# Altium schematic sheet CW308T_CEC1702_MICRO.SchDoc
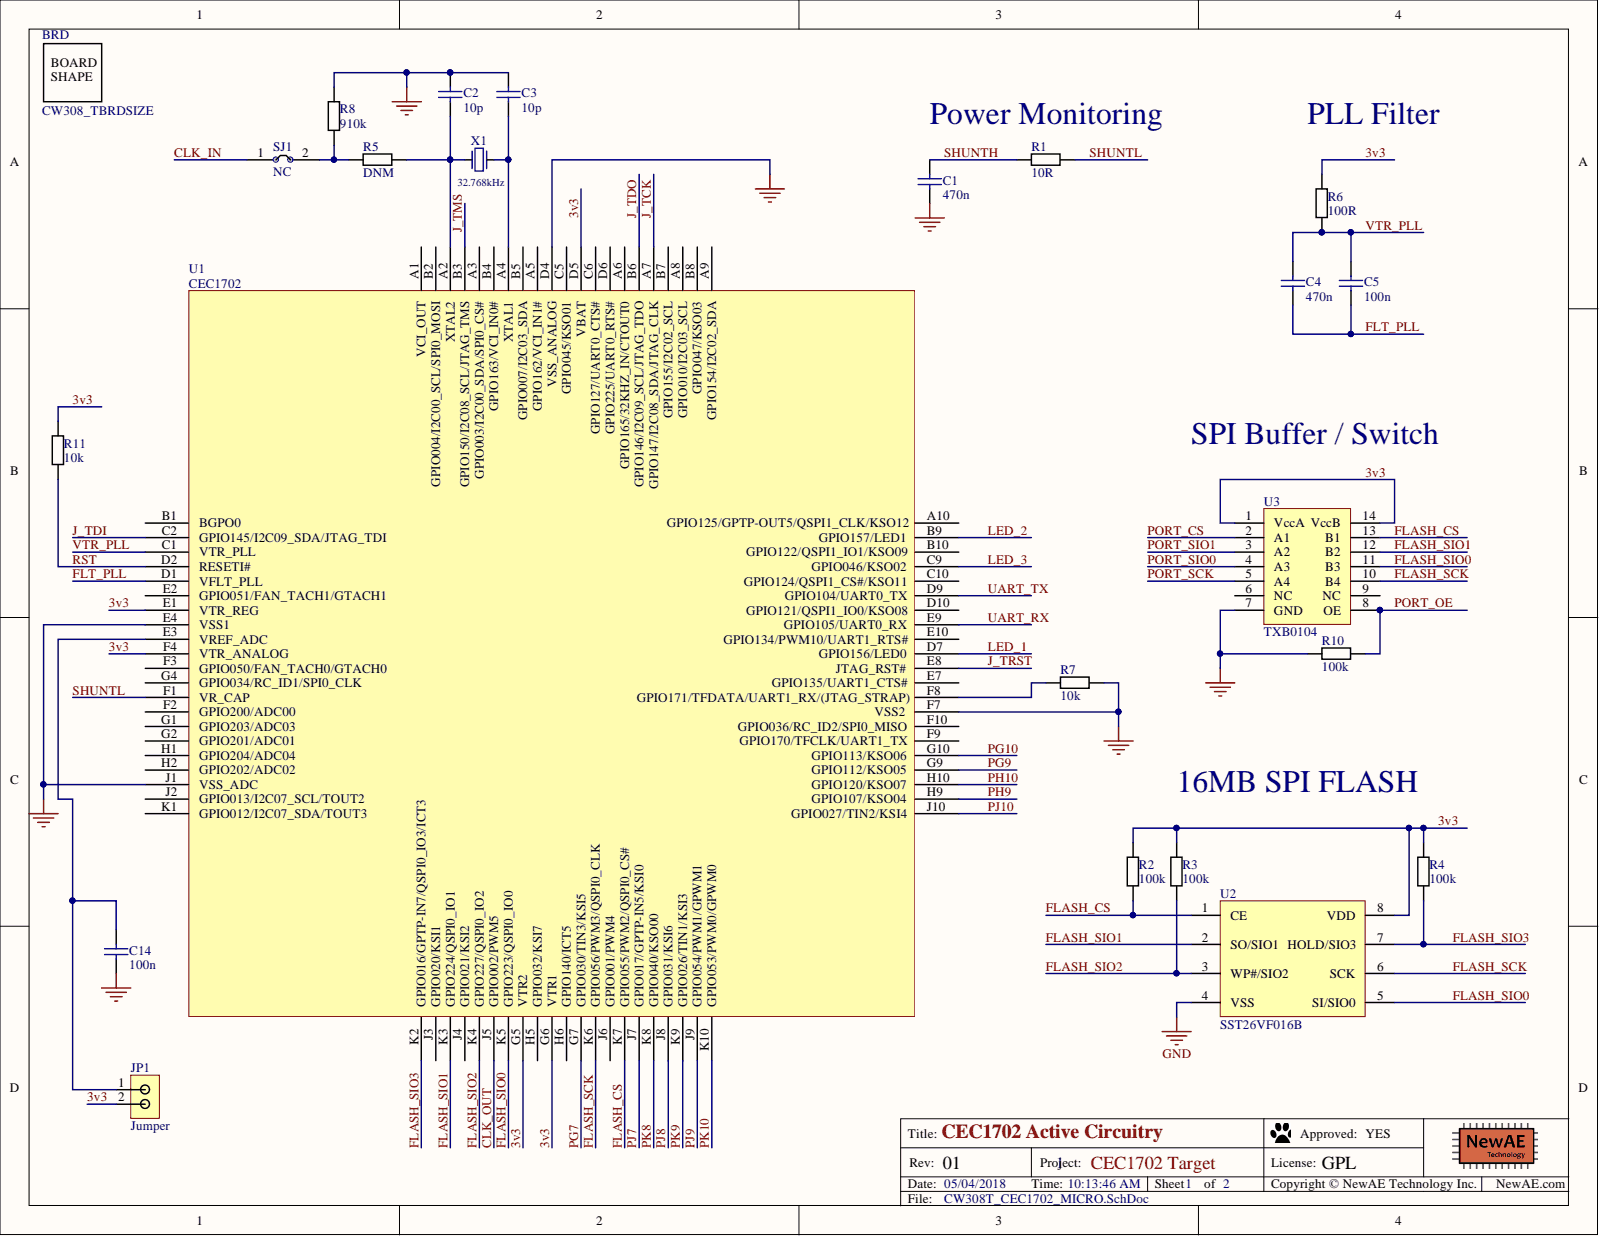
[diagram p0: CW308T_CEC1702_MICRO.SchDoc]

## Parts
BRD  CW308_TBRDSIZE  [CW308T_TBOARD_DEFSIZE]  (mechanical)
C1  470n  [0603]
C2  10p  [0603]
C3  10p  [0603]
C4  470n  [0603]
C5  100n  [0603]
C14  100n  [0603]
JP1  Jumper  [2x2.54mm_Header]
R1  10R  [0603]
R2  100k  [0603]
R3  100k  [0603]
R4  100k  [0603]
R5  DNM  [0603]
R6  100R  [0603]
R7  10k  [0603]
R8  910k  [0603]
R10  100k  [0603]
R11  10k  [0603]
SJ1  NC  [SJ_2S_NC]  (no BOM)
U1  CEC1702  [84L_WFBGA]
U2  SST26VF016B  [SOIC8]
U3  TXB0104  [SOIC14 (R-PDSO-G14)]
X1  32.768kHz  [FC-135]

## Nets
GND: C1.1 C2.1 C3.1 C14.2 R7.2 R8.1 R10.1 U1.D4(VSS_ANALOG) U1.E4(VSS1) U1.F7(VSS2) U1.J1(VSS_ADC) U2.4(VSS) U3.7(GND)
3v3: JP1.2 R2.1 R3.1 R4.1 R6.1 R11.1 U1.D5(VBAT) U1.E1(VTR_REG) U1.F4(VTR_ANALOG) U1.G5(VTR2) U1.G6(VTR1) U2.8(VDD) U3.1(VccA) U3.14(VccB)
CLK_IN: SJ1.1
CLK_OUT: U1.J5(GPIO002/PWM5)
FLASH_CS: R2.2 U1.K7(GPIO055/PWM2/QSPI0_CS#) U2.1(CE) U3.13(B1)
FLASH_SCK: U1.K6(GPIO056/PWM3/QSPI0_CLK) U2.6(SCK) U3.10(B4)
FLASH_SIO0: U1.K5(GPIO223/QSPI0_IO0) U2.5(SI/SIO0) U3.11(B3)
FLASH_SIO1: U1.K3(GPIO224/QSPI0_IO1) U2.2(SO/SIO1) U3.12(B2)
FLASH_SIO2: R3.2 U1.K4(GPIO227/QSPI0_IO2) U2.3(WP#/SIO2)
FLASH_SIO3: R4.2 U1.K2(GPIO016/GPTP-IN7/QSPI0_IO3/ICT3) U2.7(HOLD/SIO3)
FLT_PLL: C4.2 C5.2 U1.D1(VFLT_PLL)
J_TCK: U1.A7(GPIO147/I2C08_SDA/JTAG_CLK)
J_TDI: U1.C2(GPIO145/I2C09_SDA/JTAG_TDI)
J_TDO: U1.B6(GPIO146/I2C09_SCL/JTAG_TDO)
J_TMS: U1.B3(GPIO150/I2C08_SCL/JTAG_TMS)
J_TRST: U1.E8(JTAG_RST#)
LED_1: U1.D7(GPIO156/LED0)
LED_2: U1.B9(GPIO157/LED1)
LED_3: U1.C9(GPIO046/KSO02)
PG7: U1.G7(GPIO030/TIN3/KSI5)
PG9: U1.G9(GPIO112/KSO05)
PG10: U1.G10(GPIO113/KSO06)
PH9: U1.H9(GPIO107/KSO04)
PH10: U1.H10(GPIO120/KSO07)
PJ7: U1.J7(GPIO017/GPTP-IN5/KSI0)
PJ8: U1.J8(GPIO031/KSI6)
PJ9: U1.J9(GPIO054/PWM1/GPWM1)
PJ10: U1.J10(GPIO027/TIN2/KSI4)
PK8: U1.K8(GPIO040/KSO00)
PK9: U1.K9(GPIO026/TIN1/KSI3)
PK10: U1.K10(GPIO053/PWM0/GPWM0)
PORT_CS: U3.2(A1)
PORT_OE: R10.2 U3.8(OE)
PORT_SCK: U3.5(A4)
PORT_SIO0: U3.4(A3)
PORT_SIO1: U3.3(A2)
RST: R11.2 U1.D2(RESETI#)
SHUNTH: C1.2 R1.1
SHUNTL: R1.2 U1.F1(VR_CAP)
UART_RX: U1.E9(GPIO105/UART0_RX)
UART_TX: U1.D9(GPIO104/UART0_TX)
VTR_PLL: C4.1 C5.1 R6.2 U1.C1(VTR_PLL)
NetC2_2: C2.2 R5.2 U1.A2(XTAL2) X1.1
NetC3_2: C3.2 U1.A4(XTAL1) X1.2
NetC14_1: C14.1 JP1.1 U1.E3(VREF_ADC)
NetR5_1: R5.1 R8.2 SJ1.2
NetR7_1: R7.1 U1.F8(GPIO171/TFDATA/UART1_RX/(JTAG_STRAP))

Not connected: U1 A1(VCI_OUT) A3(GPIO003/I2C00_SDA/SPI0_CS#) A5(GPIO162/VCI_IN1#) A6(GPIO165/32KHZ_IN/CTOUT0) A8(GPIO010/I2C03_SCL) A9(GPIO154/I2C02_SDA) A10(GPIO125/GPTP-OUT5/QSPI1_CLK/KSO12) B1(BGPO0) B2(GPIO004/I2C00_SCL/SPI0_MOSI) B4(GPIO163/VCI_IN0#) B5(GPIO007/I2C03_SDA) B7(GPIO155/I2C02_SCL) B8(GPIO047/KSO03) B10(GPIO122/QSPI1_IO1/KSO09) C5(GPIO045/KSO01) C6(GPIO127/UART0_CTS#) C10(GPIO124/QSPI1_CS#/KSO11) D6(GPIO225/UART0_RTS#) D10(GPIO121/QSPI1_IO0/KSO08) E2(GPIO051/FAN_TACH1/GTACH1) E7(GPIO135/UART1_CTS#) E10(GPIO134/PWM10/UART1_RTS#) F2(GPIO200/ADC00) F3(GPIO050/FAN_TACH0/GTACH0) F9(GPIO170/TFCLK/UART1_TX) F10(GPIO036/RC_ID2/SPI0_MISO) G1(GPIO203/ADC03) G2(GPIO201/ADC01) G4(GPIO034/RC_ID1/SPI0_CLK) H1(GPIO204/ADC04) H2(GPIO202/ADC02) H5(GPIO032/KSI7) H6(GPIO140/ICT5) J2(GPIO013/I2C07_SCL/TOUT2) J3(GPIO020/KSI1) J4(GPIO021/KSI2) J6(GPIO001/PWM4) K1(GPIO012/I2C07_SDA/TOUT3); U3 6(NC) 9(NC)

## Library data of the parts
BRD = CW308T_BRDSHAPE_DEFAULT: 308T Board Outline (Layer 1); Component Group: Mechanical; Database Table Name: main; Component Type: Mechanical; Library Name: NewAE_AltiumLib.DBLib; Part Number: CW308T_BRDSHAPE_DEFAULT
C1 = CCHIP-470n-0603-50V: 470n, 0603, 50V; Manufacture 1: Taiyo Yuden; Manufacture Part Number 1: UMK107B7474KA-TR; Component Group: Capacitor; Database Table Name: main; Rated Voltage: 50VDC; Case-EIA: 0603; Component Kind: Standard; Component Type: Standard; Library Name: NewAE_AltiumLib.DBLib; Mounting Technology: SMT; Part Number: CCHIP-470n-0603-50V; Pin Count: 2; RoHS: Yes; Supplier 1: Digi-Key; Supplier Part Number 1: 587-3170-1-ND; Temperature Range: -55C to 125C; Value: 470n
C2 C3 = CCHIP-10p-0603: 10p, 0603; Case-Metric: 1608; Component Group: Capacitor; Database Table Name: main; Case-EIA: 0603; Component Kind: Standard; Component Type: Standard; Library Name: NewAE_AltiumLib.DBLib; Mounting Technology: SMT; Part Number: CCHIP-10p-0603; Pin Count: 2; Value: 10p
C4 = CCHIP-470n-0603-50V: 470n, 0603, 50V; Manufacture 1: Taiyo Yuden; Manufacture Part Number 1: UMK107B7474KA-TR; Component Group: Capacitor; Database Table Name: main; Case-EIA: 0603; Component Kind: Standard; Component Type: Standard; Library Name: NewAE_AltiumLib.DBLib; Mounting Technology: SMT; Part Number: CCHIP-470n-0603-50V; Pin Count: 2; Rated Voltage: 50V; RoHS: Yes; Supplier 1: Digi-Key; Supplier Part Number 1: 587-3170-1-ND; Temperature Range: -55C to 125C; Value: 470n
C5 C14 = CCHIP-100n-0603: 100n, 0603; Manufacture 1: Kemet; Manufacture Part Number 1: C0603C104K5RACTU; Case-Metric: 1608; Component Group: Capacitor; Database Table Name: main; Rated Voltage: 50V; Case-EIA: 0603; Component Kind: Standard; Component Type: Standard; Library Name: NewAE_AltiumLib.DBLib; Mounting Technology: SMT; Part Number: CCHIP-100n-0603; Pin Count: 2; Supplier 1: Digi-Key; Supplier Part Number 1: 399-5089-2-ND; Value: 100n
JP1 = 2_PIN_JUMPER: 1x2 2.54mm header, male pins; Manufacture 1: Harwin; Manufacture Part Number 1: M20-9990246; Component Group: PCB Feature; Database Table Name: main; PP Material Stack: 0; Component Kind: Standard; Component Type: Standard; Library Name: NewAE_AltiumLib.DBLib; Mounting Technology: Through-hole; Part Number: 2_PIN_JUMPER; Pin Count: 2; PP Rotation: 0; RoHS: Yes; Supplier 1: Digi-Key; Supplier Part Number 1: 952-2262-ND
R1 = RCHIP-10R-0603: 10R res, 0603, 1%, 1/10W; Manufacture 1: Yageo; Manufacture Part Number 1: RC0603FR-0710RL; Case-Metric: 1608; Component Group: Resistor; Database Table Name: main; Rated Power: 0.1W; Rated Voltage: 75VDC; Case-EIA: 0603; Component Kind: Standard; Component Type: Standard; Library Name: NewAE_AltiumLib.DBLib; Mounting Technology: SMT; Part Number: RCHIP-10R-0603; Pin Count: 2; Supplier 1: Digi-Key; Supplier Part Number 1: 311-10.0HRCT-ND; Temperature Range: -55C to 155C; Tolerance: 1%; Value: 10R
R2-R4 R10 = RCHIP-100K-0603: 100k res, 0603, 1%, 1/10W; Manufacture 1: Yageo; Manufacture Part Number 1: RC0603FR-07100KL; Case-Metric: 1608; Component Group: Resistor; Database Table Name: main; Rated Power: 0.1W; Rated Voltage: 75VDC; Case-EIA: 0603; Component Kind: Standard; Component Type: Standard; Library Name: NewAE_AltiumLib.DBLib; Mounting Technology: SMT; Part Number: RCHIP-100K-0603; Pin Count: 2; Supplier 1: Digi-Key; Supplier Part Number 1: 311-100KHRCT-ND; Temperature Range: -55C to 155C; Tolerance: 1%; Value: 100k
R5 R6 = RCHIP-100R-0603: 100R res, 0603, 1%, 1/10W; Manufacture 1: Yageo; Manufacture Part Number 1: RC0603FR-07100RL; Case-Metric: 1608; Component Group: Resistor; Database Table Name: main; Rated Power: 0.1W; Rated Voltage: 75VDC; Case-EIA: 0603; Component Kind: Standard; Component Type: Standard; Library Name: NewAE_AltiumLib.DBLib; Mounting Technology: SMT; Part Number: RCHIP-100R-0603; Pin Count: 2; Supplier 1: Digi-Key; Supplier Part Number 1: 311-100HRCT-ND; Temperature Range: -55C to 155C; Tolerance: 1%; Value: 100R
R7 R11 = RCHIP-10K-0603: 10k res, 0603, 1%, 1/10W; Manufacture 1: Yageo; Manufacture Part Number 1: RC0603FR-0710KL; Case-Metric: 1608; Component Group: Resistor; Database Table Name: main; Rated Power: 0.1W; Rated Voltage: 75VDC; Case-EIA: 0603; Component Kind: Standard; Component Type: Standard; Library Name: NewAE_AltiumLib.DBLib; Mounting Technology: SMT; Part Number: RCHIP-10K-0603; Pin Count: 2; Supplier 1: Digi-Key; Supplier Part Number 1: 311-10.0KHRCT-ND; Temperature Range: -55C to 155C; Tolerance: 1%; Value: 10k
R8 = RCHIP-910K-0603: 910k res, 0603, 1%, 1/10W; Manufacture 1: Yageo; Manufacture Part Number 1: RC0603FR-07910KL; Case-Metric: 1608; Component Group: Resistor; Database Table Name: main; Rated Power: 0.1W; Rated Voltage: 75VDC; Case-EIA: 0603; Component Kind: Standard; Component Type: Standard; Library Name: NewAE_AltiumLib.DBLib; Mounting Technology: SMT; Part Number: RCHIP-910K-0603; Pin Count: 2; Supplier 1: Digi-Key; Supplier Part Number 1: 311-910KHRCT-ND; Temperature Range: -55C to 155C; Tolerance: 1%; Value: 910k
SJ1 = SJ_2S: Solder Jumper, NC; Component Group: PCB Feature; Database Table Name: main; Component Type: Standard (No BOM); Library Name: NewAE_AltiumLib.DBLib; Mounting Technology: COPPER; Part Number: SJ_2S; Pin Count: 2
U1 = CEC1702: CEC1702, 84WFBGA, microchip hardware crypto; Manufacture Part Number 1: CEC1702Q-B1-SX-TR; Component Group: Digital IC; Database Table Name: main; PP Material Stack: 0; Component Kind: Standard; ComponentLink1Description: Datasheet; ComponentLink1URL: http://ww1.microchip.com/downloads/en/DeviceDoc/00002207B.pdf; Component Type: Standard; Library Name: NewAE_AltiumLib.DBLib; Manufacture 1: Microchip; Mounting Technology: SMT; Part Number: CEC1702; Pin Count: 84; PP Rotation: 0; RoHS: Yes; Supplier 1: Digi-Key; Supplier Part Number 1: CEC1702Q-B1-SX-CT-ND
U2 = SST26VF016B: IC FLASH 16MBIT 104MHZ 8SOIC; Manufacture 1: Microchip; Manufacture Part Number 1: SST26VF016B-104I/SN; Component Group: Digital IC; Database Table Name: main; PP Material Stack: 0; Component Kind: Standard; Component Type: Standard; Library Name: NewAE_AltiumLib.DBLib; Mounting Technology: SMT; Part Number: SST26VF016B; Pin Count: 8; PP Rotation: 0; Supplier 1: Digi-Key; Supplier Part Number 1: SST26VF016B-104I/SN-ND
U3 = TXB0104DR: IC 4BIT NON-INV TRANSLTR 14-SOIC; Manufacture 1: Texas Instruments; Component Group: Digital IC; Database Table Name: main; PP Material Stack: 0; Component Kind: Standard; Component Type: Standard; Library Name: NewAE_AltiumLib.DBLib; Manufacture Part Number 1: TXB0104DR; Mounting Technology: SMT; Part Number: TXB0104DR; Pin Count: 14; PP Rotation: 0; RoHS: Yes; Supplier 1: Digi-Key; Supplier Part Number 1: 296-21928-1-ND
X1 = FC-135: Crystal, 32kHz, 32.768kHz, 2-pin; Manufacture 1: EPSON; Manufacture Part Number 1: FC-135 32.7680KA-K0; Database Table Name: main; PP Material Stack: 0; Component Group: Crystal; Component Kind: Standard; Component Type: Standard; Library Name: NewAE_AltiumLib.DBLib; Mounting Technology: SMT; Part Number: FC-135; Pin Count: 2; PP Rotation: 0; Supplier 1: Digi-Key; Supplier Part Number 1: SER4087CT-ND
